# Altium schematic sheet Peripheral.SchDoc
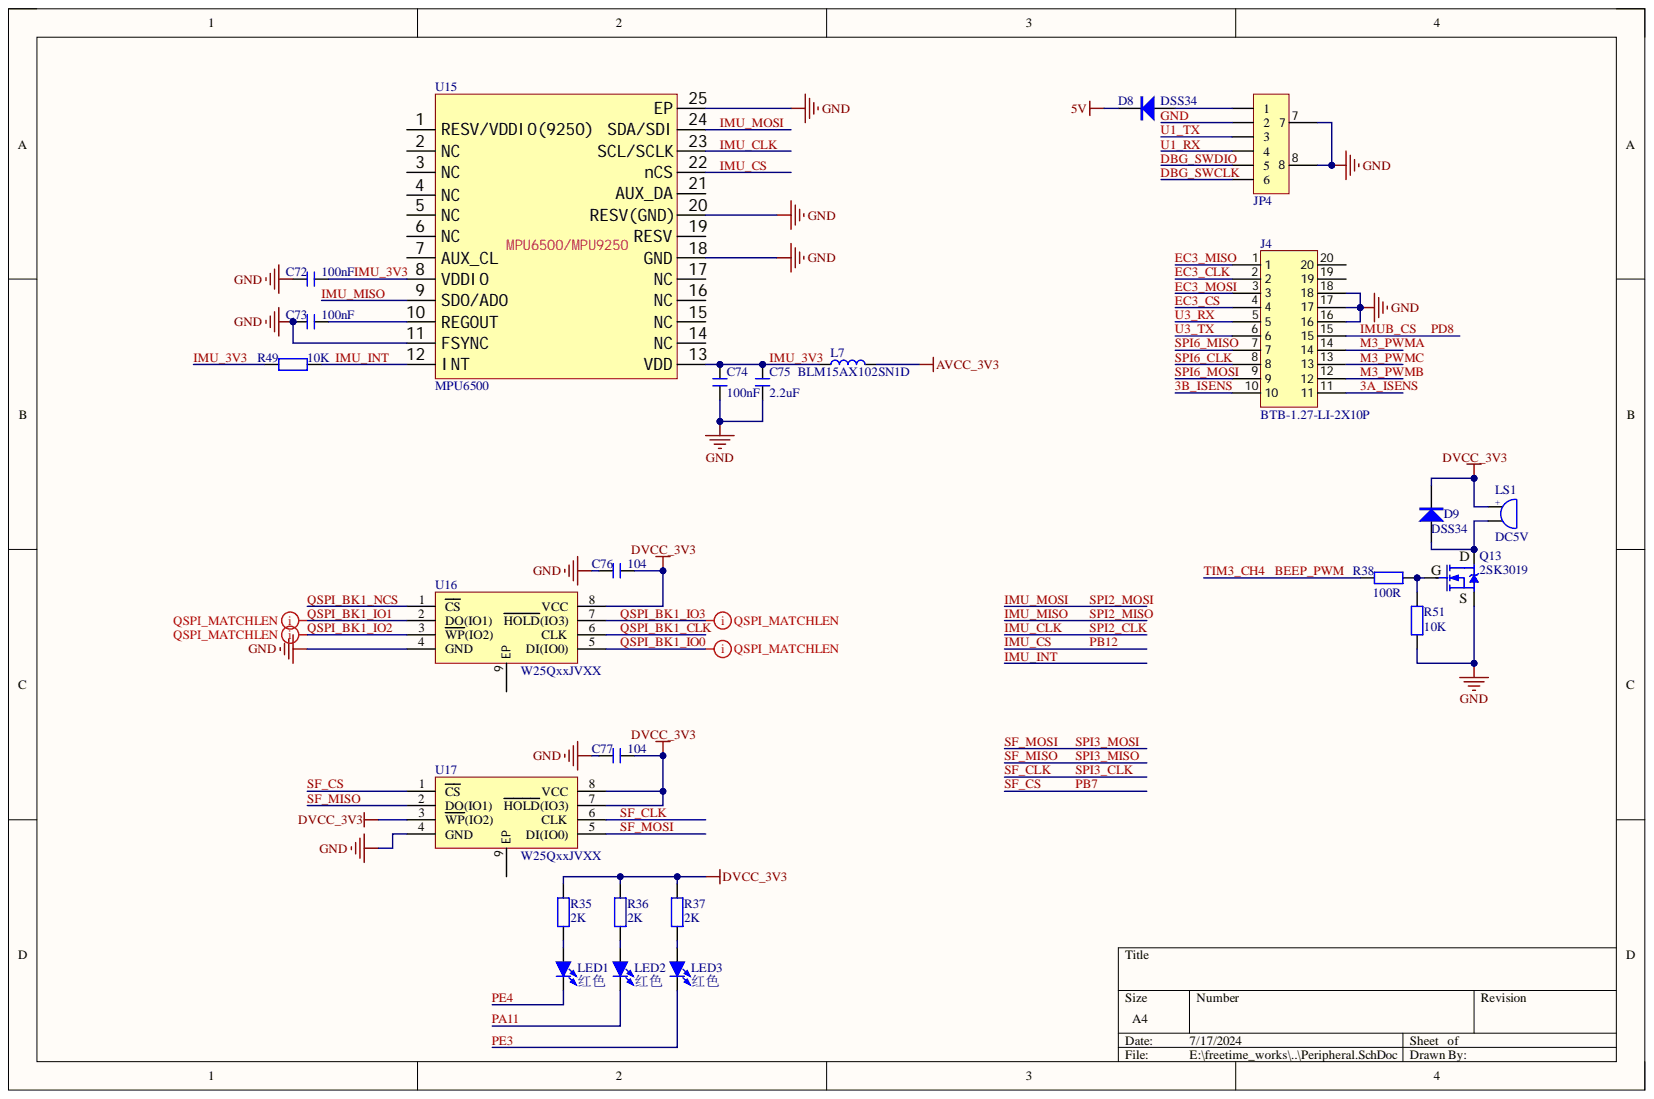
[diagram p0: Peripheral.SchDoc]

## Parts
C72  100nF  [C 0402_L]
C73  100nF  [C 0402_L]
C74  100nF  [C 0402_L]
C75  2.2uF  [C 0402_L]
C76  104  [C 0402_L]
C77  104  [C 0402_L]
D8  DSS34  [SOD-123FL]
D9  DSS34  [SOD-123FL]
J4  BTB-1.27-LI-2X10P  [1.27-LI-2X10P]
JP4  HDR-1X6  [GH1.25-WS-6P]  (value not drawn)
L7  BLM15AX102SN1D  [L 0402]
LED1  红色  [LED 0402R]
LED2  红色  [LED 0402R]
LED3  红色  [LED 0402R]
LS1  DC5V  [BEEP_SMD_SBZ9032C03R16]
Q13  2SK3019  [SOT523]
R35  2K  [R 0402_L]
R36  2K  [R 0402_L]
R37  2K  [R 0402_L]
R38  100R  [R 0402_L]
R49  10K  [R 0402_L]
R51  10K  [R 0402_L]
U15  MPU6500  [QFN24 3X3]
U16  W25QxxJVXX  [SOP8W_L]
U17  W25QxxJVXX  [SOP8W_L]

## Nets
5V: D8.1
AVCC_3V3: L7.2
DVCC_3V3: C76.2 C77.2 D9.1 LS1.1 R35.2 R36.2 R37.2 U16.8(VCC) U17.3(WP(IO2)) U17.7(HOLD(IO3)) U17.8(VCC)
3A_ISENS: J4.11
3B_ISENS: J4.10
BEEP_PWM: R38.1
DBG_SWCLK: JP4.6
DBG_SWDIO: JP4.5
EC3_CLK: J4.2
EC3_CS: J4.4
EC3_MISO: J4.1
EC3_MOSI: J4.3
GND: C72.2 C73.2 C74.1 C75.1 C76.1 C77.1 J4.16 J4.17 J4.18 JP4.2 JP4.7 JP4.8 Q13.2 R51.1 U15.11(FSYNC) U15.18(GND) U15.20(RESV(GND)) U15.25(EP) U16.4(GND) U17.4(GND)
IMU_3V3: C72.1 C74.2 C75.2 L7.1 R49.1 U15.8(VDDIO) U15.13(VDD)
IMU_CLK: U15.23(SCL/SCLK)
IMU_CS: U15.22(nCS)
IMU_INT: R49.2 U15.12(INT)
IMU_MISO: U15.9(SDO/AD0)
IMU_MOSI: U15.24(SDA/SDI)
IMUB_CS: J4.15
M3_PWMA: J4.14
M3_PWMB: J4.12
M3_PWMC: J4.13
PA11: LED2.1
PB7: U17.1(CS)
PE3: LED3.1
PE4: LED1.1
QSPI_BK1_CLK: U16.6(CLK)
QSPI_BK1_IO0: U16.5(DI(IO0))
QSPI_BK1_IO1: U16.2(DO(IO1))
QSPI_BK1_IO2: U16.3(WP(IO2))
QSPI_BK1_IO3: U16.7(HOLD(IO3))
QSPI_BK1_NCS: U16.1(CS)
SF_CLK: U17.6(CLK)
SF_MISO: U17.2(DO(IO1))
SF_MOSI: U17.5(DI(IO0))
SPI6_CLK: J4.8
SPI6_MISO: J4.7
SPI6_MOSI: J4.9
U1_RX: JP4.4
U1_TX: JP4.3
U3_RX: J4.5
U3_TX: J4.6
NetC73_1: C73.1 U15.10(REGOUT)
NetD8_2: D8.2 JP4.1
NetD9_2: D9.2 LS1.2 Q13.3
NetLED1_2: LED1.2 R35.1
NetLED2_2: LED2.2 R36.1
NetLED3_2: LED3.2 R37.1
NetQ13_1: Q13.1 R38.2 R51.2

Not connected: J4 19 20; U15 1(RESV/VDDIO(9250)) 2(NC) 3(NC) 4(NC) 5(NC) 6(NC) 7(AUX_CL) 14(NC) 15(NC) 16(NC) 17(NC) 19(RESV) 21(AUX_DA); U16 9(EP); U17 9(EP)

## Library data of the parts
C72-C77 = C: 无极性贴片电容; 版权归属: 源创客; BOM名称: 无极性贴片电容; Component Kind: Standard; Library Name: 3.1   - 电阻-电容-电感.SchLib; 学习资源: www.ooocke.com
D8 D9 = DSS34: 肖特基二极管; Component Kind: Standard; Library Name: 8.1   - 二极管-整流桥.SchLib
J4 = LI-2X10P: Component Kind: Standard; Library Name: 4.16 - BTB连接器 - 1.27.SchLib
JP4 = Header 6: 6P接插件; 版权归属: 源创客; BOM名称: 6P接插件; Component Kind: Standard; Library Name: 4.2   - 接插件-脚距1.25.SchLib; 学习资源: www.ooocke.com
L7 = L: 小功率贴片电感; 版权归属: 源创客; BOM名称: 小功率贴片电感; Component Kind: Standard; Library Name: 3.1   - 电阻-电容-电感.SchLib; 学习资源: www.ooocke.com
LED1-LED3 = LED-SMD: 贴片LED; 版权归属: 源创客; BOM名称: 贴片LED; Component Kind: Standard; Library Name: 9.1   - LED.SchLib; 学习资源: www.ooocke.com
LS1 = BEEP: 蜂鸣器; 版权归属: 源创客; BOM名称: 蜂鸣器; Component Kind: Standard; Library Name: 15.1 - 未分类器件.SchLib; 学习资源: www.ooocke.com
Q13 = 2SK3019: N沟道场效应管; Component Kind: Standard; Library Name: 6.1   - 场效应管-可控硅.SchLib
R35-R38 R49 R51 = R: 贴片电阻; 版权归属: 源创客; BOM名称: 贴片电阻; Component Kind: Standard; Library Name: 3.1   - 电阻-电容-电感.SchLib; 学习资源: www.ooocke.com
U15 = MPU6500: 6轴IMU; Component Kind: Standard; Library Name: 11.1 - 传感元件.SchLib
U16 U17 = W25Qxx: 外置Flash; 版权归属: 源创客; BOM名称: 外置Flash; Component Kind: Standard; Library Name: 1.1   - IC芯片.SchLib; 学习资源: www.ooocke.com
